# Altium schematic sheet LEDs.SchDoc(LEDs)
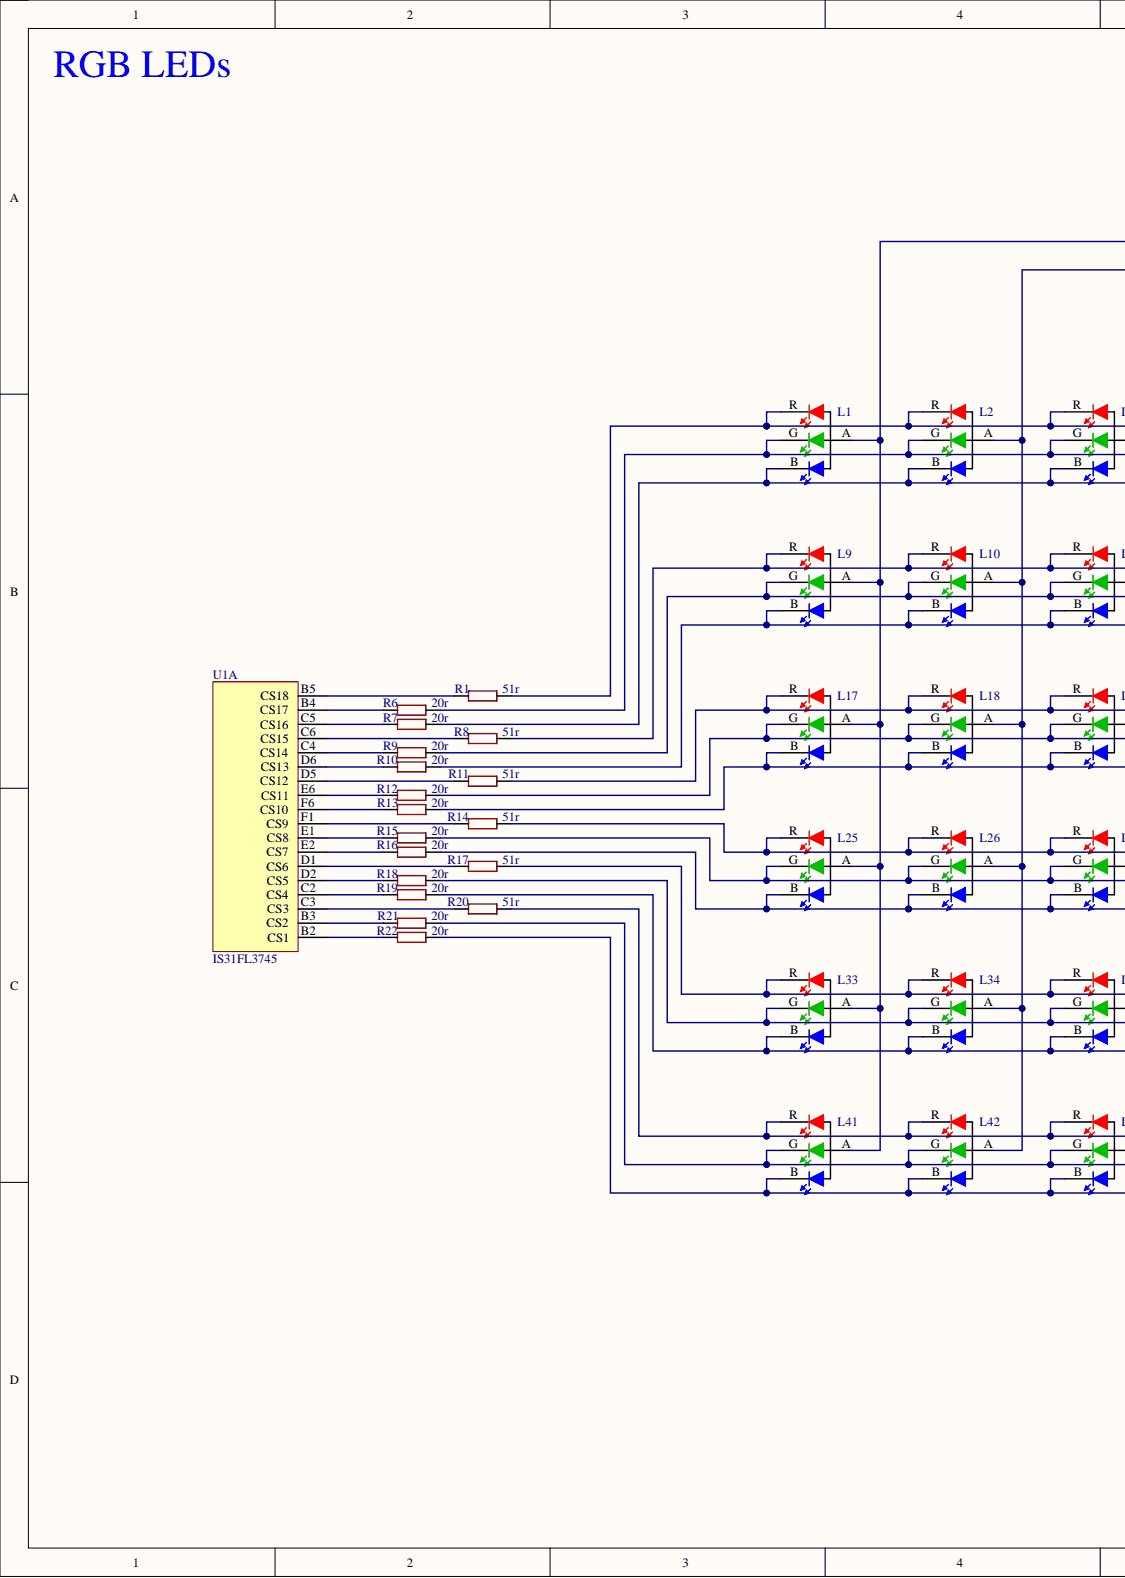
[diagram p0: LEDs.SchDoc, left]
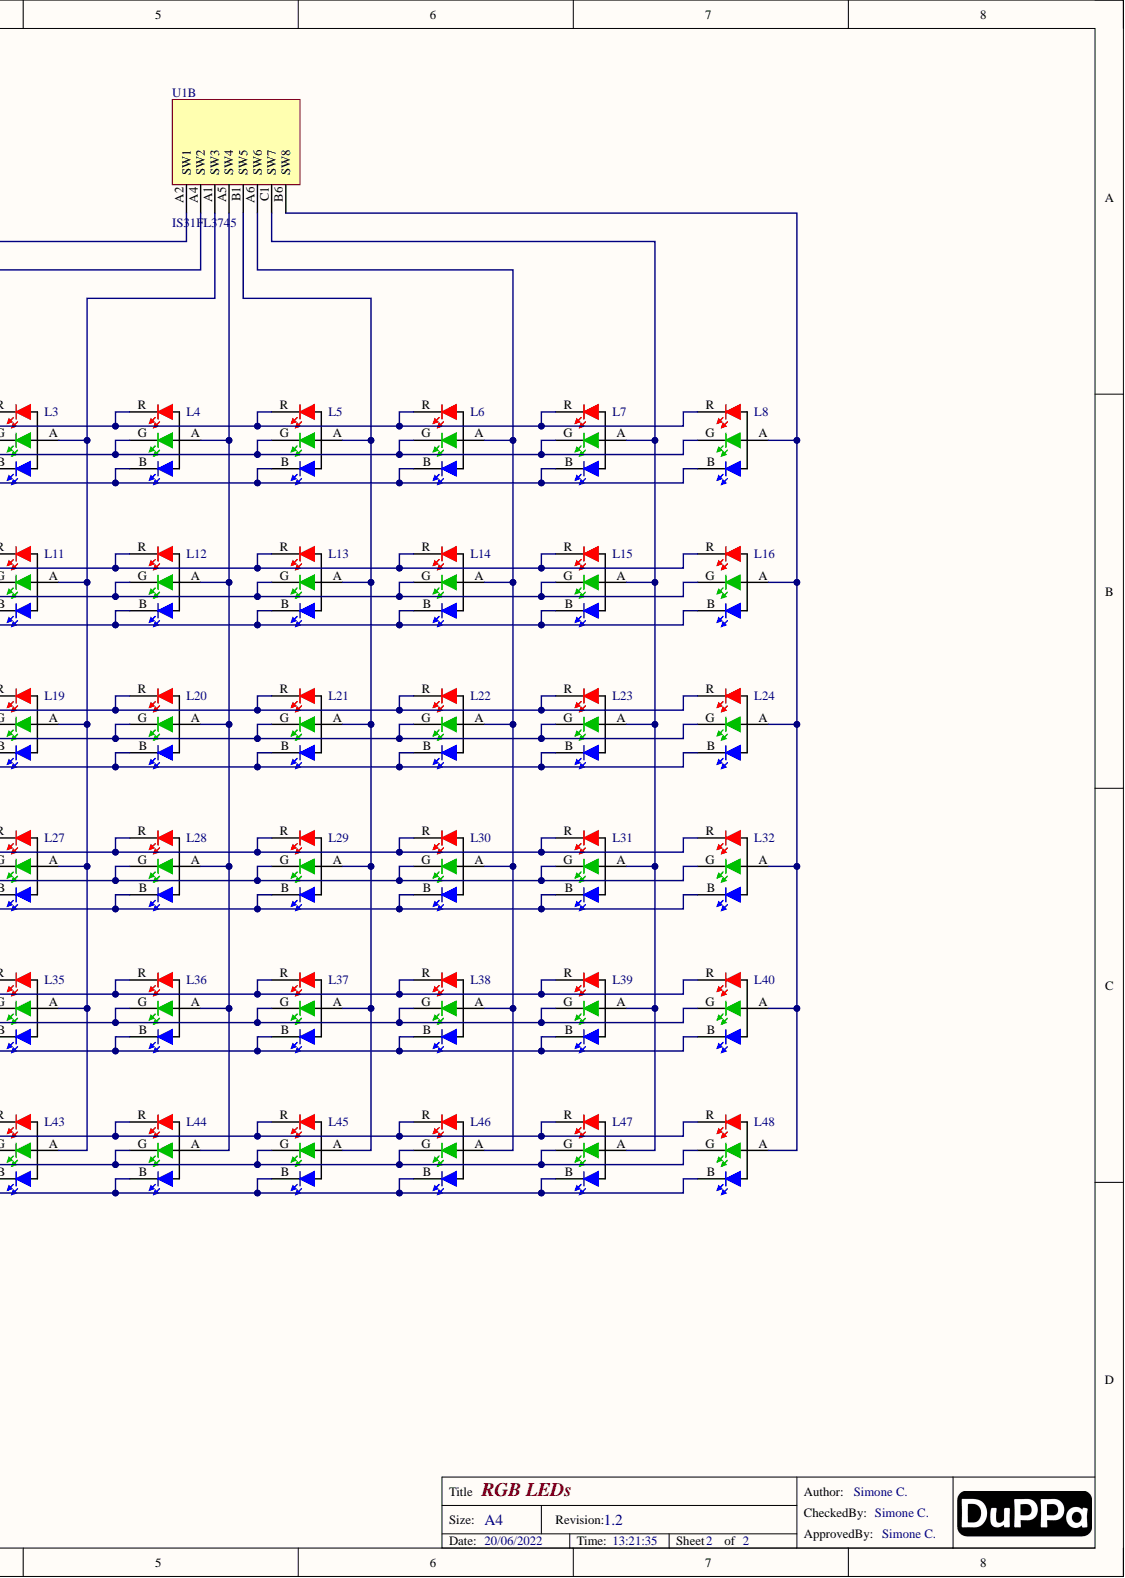
[diagram p1: LEDs.SchDoc, right]

## Parts
L1  RGB_2020_NH-Z1921RGBA-SG  [RGB_2121_NH-Z1921RGBA-SG]  (value not drawn)
L2  RGB_2020_NH-Z1921RGBA-SG  [RGB_2121_NH-Z1921RGBA-SG]  (value not drawn)
L3  RGB_2020_NH-Z1921RGBA-SG  [RGB_2121_NH-Z1921RGBA-SG]  (value not drawn)
L4  RGB_2020_NH-Z1921RGBA-SG  [RGB_2121_NH-Z1921RGBA-SG]  (value not drawn)
L5  RGB_2020_NH-Z1921RGBA-SG  [RGB_2121_NH-Z1921RGBA-SG]  (value not drawn)
L6  RGB_2020_NH-Z1921RGBA-SG  [RGB_2121_NH-Z1921RGBA-SG]  (value not drawn)
L7  RGB_2020_NH-Z1921RGBA-SG  [RGB_2121_NH-Z1921RGBA-SG]  (value not drawn)
L8  RGB_2020_NH-Z1921RGBA-SG  [RGB_2121_NH-Z1921RGBA-SG]  (value not drawn)
L9  RGB_2020_NH-Z1921RGBA-SG  [RGB_2121_NH-Z1921RGBA-SG]  (value not drawn)
L10  RGB_2020_NH-Z1921RGBA-SG  [RGB_2121_NH-Z1921RGBA-SG]  (value not drawn)
L11  RGB_2020_NH-Z1921RGBA-SG  [RGB_2121_NH-Z1921RGBA-SG]  (value not drawn)
L12  RGB_2020_NH-Z1921RGBA-SG  [RGB_2121_NH-Z1921RGBA-SG]  (value not drawn)
L13  RGB_2020_NH-Z1921RGBA-SG  [RGB_2121_NH-Z1921RGBA-SG]  (value not drawn)
L14  RGB_2020_NH-Z1921RGBA-SG  [RGB_2121_NH-Z1921RGBA-SG]  (value not drawn)
L15  RGB_2020_NH-Z1921RGBA-SG  [RGB_2121_NH-Z1921RGBA-SG]  (value not drawn)
L16  RGB_2020_NH-Z1921RGBA-SG  [RGB_2121_NH-Z1921RGBA-SG]  (value not drawn)
L17  RGB_2020_NH-Z1921RGBA-SG  [RGB_2121_NH-Z1921RGBA-SG]  (value not drawn)
L18  RGB_2020_NH-Z1921RGBA-SG  [RGB_2121_NH-Z1921RGBA-SG]  (value not drawn)
L19  RGB_2020_NH-Z1921RGBA-SG  [RGB_2121_NH-Z1921RGBA-SG]  (value not drawn)
L20  RGB_2020_NH-Z1921RGBA-SG  [RGB_2121_NH-Z1921RGBA-SG]  (value not drawn)
L21  RGB_2020_NH-Z1921RGBA-SG  [RGB_2121_NH-Z1921RGBA-SG]  (value not drawn)
L22  RGB_2020_NH-Z1921RGBA-SG  [RGB_2121_NH-Z1921RGBA-SG]  (value not drawn)
L23  RGB_2020_NH-Z1921RGBA-SG  [RGB_2121_NH-Z1921RGBA-SG]  (value not drawn)
L24  RGB_2020_NH-Z1921RGBA-SG  [RGB_2121_NH-Z1921RGBA-SG]  (value not drawn)
L25  RGB_2020_NH-Z1921RGBA-SG  [RGB_2121_NH-Z1921RGBA-SG]  (value not drawn)
L26  RGB_2020_NH-Z1921RGBA-SG  [RGB_2121_NH-Z1921RGBA-SG]  (value not drawn)
L27  RGB_2020_NH-Z1921RGBA-SG  [RGB_2121_NH-Z1921RGBA-SG]  (value not drawn)
L28  RGB_2020_NH-Z1921RGBA-SG  [RGB_2121_NH-Z1921RGBA-SG]  (value not drawn)
L29  RGB_2020_NH-Z1921RGBA-SG  [RGB_2121_NH-Z1921RGBA-SG]  (value not drawn)
L30  RGB_2020_NH-Z1921RGBA-SG  [RGB_2121_NH-Z1921RGBA-SG]  (value not drawn)
L31  RGB_2020_NH-Z1921RGBA-SG  [RGB_2121_NH-Z1921RGBA-SG]  (value not drawn)
L32  RGB_2020_NH-Z1921RGBA-SG  [RGB_2121_NH-Z1921RGBA-SG]  (value not drawn)
L33  RGB_2020_NH-Z1921RGBA-SG  [RGB_2121_NH-Z1921RGBA-SG]  (value not drawn)
L34  RGB_2020_NH-Z1921RGBA-SG  [RGB_2121_NH-Z1921RGBA-SG]  (value not drawn)
L35  RGB_2020_NH-Z1921RGBA-SG  [RGB_2121_NH-Z1921RGBA-SG]  (value not drawn)
L36  RGB_2020_NH-Z1921RGBA-SG  [RGB_2121_NH-Z1921RGBA-SG]  (value not drawn)
L37  RGB_2020_NH-Z1921RGBA-SG  [RGB_2121_NH-Z1921RGBA-SG]  (value not drawn)
L38  RGB_2020_NH-Z1921RGBA-SG  [RGB_2121_NH-Z1921RGBA-SG]  (value not drawn)
L39  RGB_2020_NH-Z1921RGBA-SG  [RGB_2121_NH-Z1921RGBA-SG]  (value not drawn)
L40  RGB_2020_NH-Z1921RGBA-SG  [RGB_2121_NH-Z1921RGBA-SG]  (value not drawn)
L41  RGB_2020_NH-Z1921RGBA-SG  [RGB_2121_NH-Z1921RGBA-SG]  (value not drawn)
L42  RGB_2020_NH-Z1921RGBA-SG  [RGB_2121_NH-Z1921RGBA-SG]  (value not drawn)
L43  RGB_2020_NH-Z1921RGBA-SG  [RGB_2121_NH-Z1921RGBA-SG]  (value not drawn)
L44  RGB_2020_NH-Z1921RGBA-SG  [RGB_2121_NH-Z1921RGBA-SG]  (value not drawn)
L45  RGB_2020_NH-Z1921RGBA-SG  [RGB_2121_NH-Z1921RGBA-SG]  (value not drawn)
L46  RGB_2020_NH-Z1921RGBA-SG  [RGB_2121_NH-Z1921RGBA-SG]  (value not drawn)
L47  RGB_2020_NH-Z1921RGBA-SG  [RGB_2121_NH-Z1921RGBA-SG]  (value not drawn)
L48  RGB_2020_NH-Z1921RGBA-SG  [RGB_2121_NH-Z1921RGBA-SG]  (value not drawn)
R1  value 51r  [R0402]  (hidden comment: R-EU_R0402)
R6  value 20r  [R0402]  (hidden comment: R-EU_R0402)
R7  value 20r  [R0402]  (hidden comment: R-EU_R0402)
R8  value 51r  [R0402]  (hidden comment: R-EU_R0402)
R9  value 20r  [R0402]  (hidden comment: R-EU_R0402)
R10  value 20r  [R0402]  (hidden comment: R-EU_R0402)
R11  value 51r  [R0402]  (hidden comment: R-EU_R0402)
R12  value 20r  [R0402]  (hidden comment: R-EU_R0402)
R13  value 20r  [R0402]  (hidden comment: R-EU_R0402)
R14  value 51r  [R0402]  (hidden comment: R-EU_R0402)
R15  value 20r  [R0402]  (hidden comment: R-EU_R0402)
R16  value 20r  [R0402]  (hidden comment: R-EU_R0402)
R17  value 51r  [R0402]  (hidden comment: R-EU_R0402)
R18  value 20r  [R0402]  (hidden comment: R-EU_R0402)
R19  value 20r  [R0402]  (hidden comment: R-EU_R0402)
R20  value 51r  [R0402]  (hidden comment: R-EU_R0402)
R21  value 20r  [R0402]  (hidden comment: R-EU_R0402)
R22  value 20r  [R0402]  (hidden comment: R-EU_R0402)
U1  IS31FL3745  [BGA36C50P6X6_290X290X40]  (parts A,B)

## Nets
NetL1_A: L1.A L9.A L17.A L25.A L33.A L41.A U1.A2(SW1)
NetL1_B: L1.B L2.B L3.B L4.B L5.B L6.B L7.B L8.B R7.1
NetL1_G: L1.G L2.G L3.G L4.G L5.G L6.G L7.G L8.G R6.1
NetL1_R: L1.R L2.R L3.R L4.R L5.R L6.R L7.R L8.R R1.1
NetL2_A: L2.A L10.A L18.A L26.A L34.A L42.A U1.A4(SW2)
NetL3_A: L3.A L11.A L19.A L27.A L35.A L43.A U1.A1(SW3)
NetL4_A: L4.A L12.A L20.A L28.A L36.A L44.A U1.A5(SW4)
NetL5_A: L5.A L13.A L21.A L29.A L37.A L45.A U1.B1(SW5)
NetL6_A: L6.A L14.A L22.A L30.A L38.A L46.A U1.A6(SW6)
NetL7_A: L7.A L15.A L23.A L31.A L39.A L47.A U1.C1(SW7)
NetL8_A: L8.A L16.A L24.A L32.A L40.A L48.A U1.B6(SW8)
NetL9_B: L9.B L10.B L11.B L12.B L13.B L14.B L15.B L16.B R10.1
NetL9_G: L9.G L10.G L11.G L12.G L13.G L14.G L15.G L16.G R9.1
NetL9_R: L9.R L10.R L11.R L12.R L13.R L14.R L15.R L16.R R8.1
NetL17_B: L17.B L18.B L19.B L20.B L21.B L22.B L23.B L24.B R13.1
NetL17_G: L17.G L18.G L19.G L20.G L21.G L22.G L23.G L24.G R12.1
NetL17_R: L17.R L18.R L19.R L20.R L21.R L22.R L23.R L24.R R11.1
NetL25_B: L25.B L26.B L27.B L28.B L29.B L30.B L31.B L32.B R16.1
NetL25_G: L25.G L26.G L27.G L28.G L29.G L30.G L31.G L32.G R15.1
NetL25_R: L25.R L26.R L27.R L28.R L29.R L30.R L31.R L32.R R14.1
NetL33_B: L33.B L34.B L35.B L36.B L37.B L38.B L39.B L40.B R19.1
NetL33_G: L33.G L34.G L35.G L36.G L37.G L38.G L39.G L40.G R18.1
NetL33_R: L33.R L34.R L35.R L36.R L37.R L38.R L39.R L40.R R17.1
NetL41_B: L41.B L42.B L43.B L44.B L45.B L46.B L47.B L48.B R22.1
NetL41_G: L41.G L42.G L43.G L44.G L45.G L46.G L47.G L48.G R21.1
NetL41_R: L41.R L42.R L43.R L44.R L45.R L46.R L47.R L48.R R20.1
NetR1_2: R1.2 U1.B5(CS18)
NetR6_2: R6.2 U1.B4(CS17)
NetR7_2: R7.2 U1.C5(CS16)
NetR8_2: R8.2 U1.C6(CS15)
NetR9_2: R9.2 U1.C4(CS14)
NetR10_2: R10.2 U1.D6(CS13)
NetR11_2: R11.2 U1.D5(CS12)
NetR12_2: R12.2 U1.E6(CS11)
NetR13_2: R13.2 U1.F6(CS10)
NetR14_2: R14.2 U1.F1(CS9)
NetR15_2: R15.2 U1.E1(CS8)
NetR16_2: R16.2 U1.E2(CS7)
NetR17_2: R17.2 U1.D1(CS6)
NetR18_2: R18.2 U1.D2(CS5)
NetR19_2: R19.2 U1.C2(CS4)
NetR20_2: R20.2 U1.C3(CS3)
NetR21_2: R21.2 U1.B3(CS2)
NetR22_2: R22.2 U1.B2(CS1)

## Library data of the parts
R1 R8 R11 R14 R17 R20 = R-EU_R0402: PartNumber: RC0402JR-0751RL; Component Kind: Standard; Library Name: rcl.IntLib
R6 R7 R9 R10 R12 R13 R15 R16 R18 R19 R21 R22 = R-EU_R0402: PartNumber: RC0402JR-0720RL; Component Kind: Standard; Library Name: rcl.IntLib
U1 = IS31FL3745: 18×8 DOTS MATRIX LED DRIVER; Manufacturer: ISSI; Manufacturer Part Number: IS31FL3745-CLS4-TR; Category: LED Drivers; Component Kind: Standard; ComponentLink1Description: http://www.issi.com/WW/pdf/31FL3745.pdf?src-supplier=Digi-Key; ComponentLink1URL: http://www.issi.com/WW/pdf/31FL3745.pdf?src-supplier=Digi-Key; Library Name: ISSI.IntLib; Packaging: Tape and Reel; PartNumber: IS31FL3745; Pricing 1: 1=3,20000, 10=2,87600, 25=2,71880, 100=2,35640, 250=2,23552, 500=2,00594, 1000=1,71000 (USD); RoHS: No; RoHS Compliant: No; Stock 1: 2480; Supplier 1: Digi-Key; Supplier Part Number 1: 706-1673-1-ND
L1-L48 = RGB_2020_NH-Z1921RGBA-SG: Component Kind: Standard; Library Name: Miscellaneous Devices.IntLib; PartNumber: NH-Z1921RGBA-SG
